# Altium schematic sheet Power.SchDoc
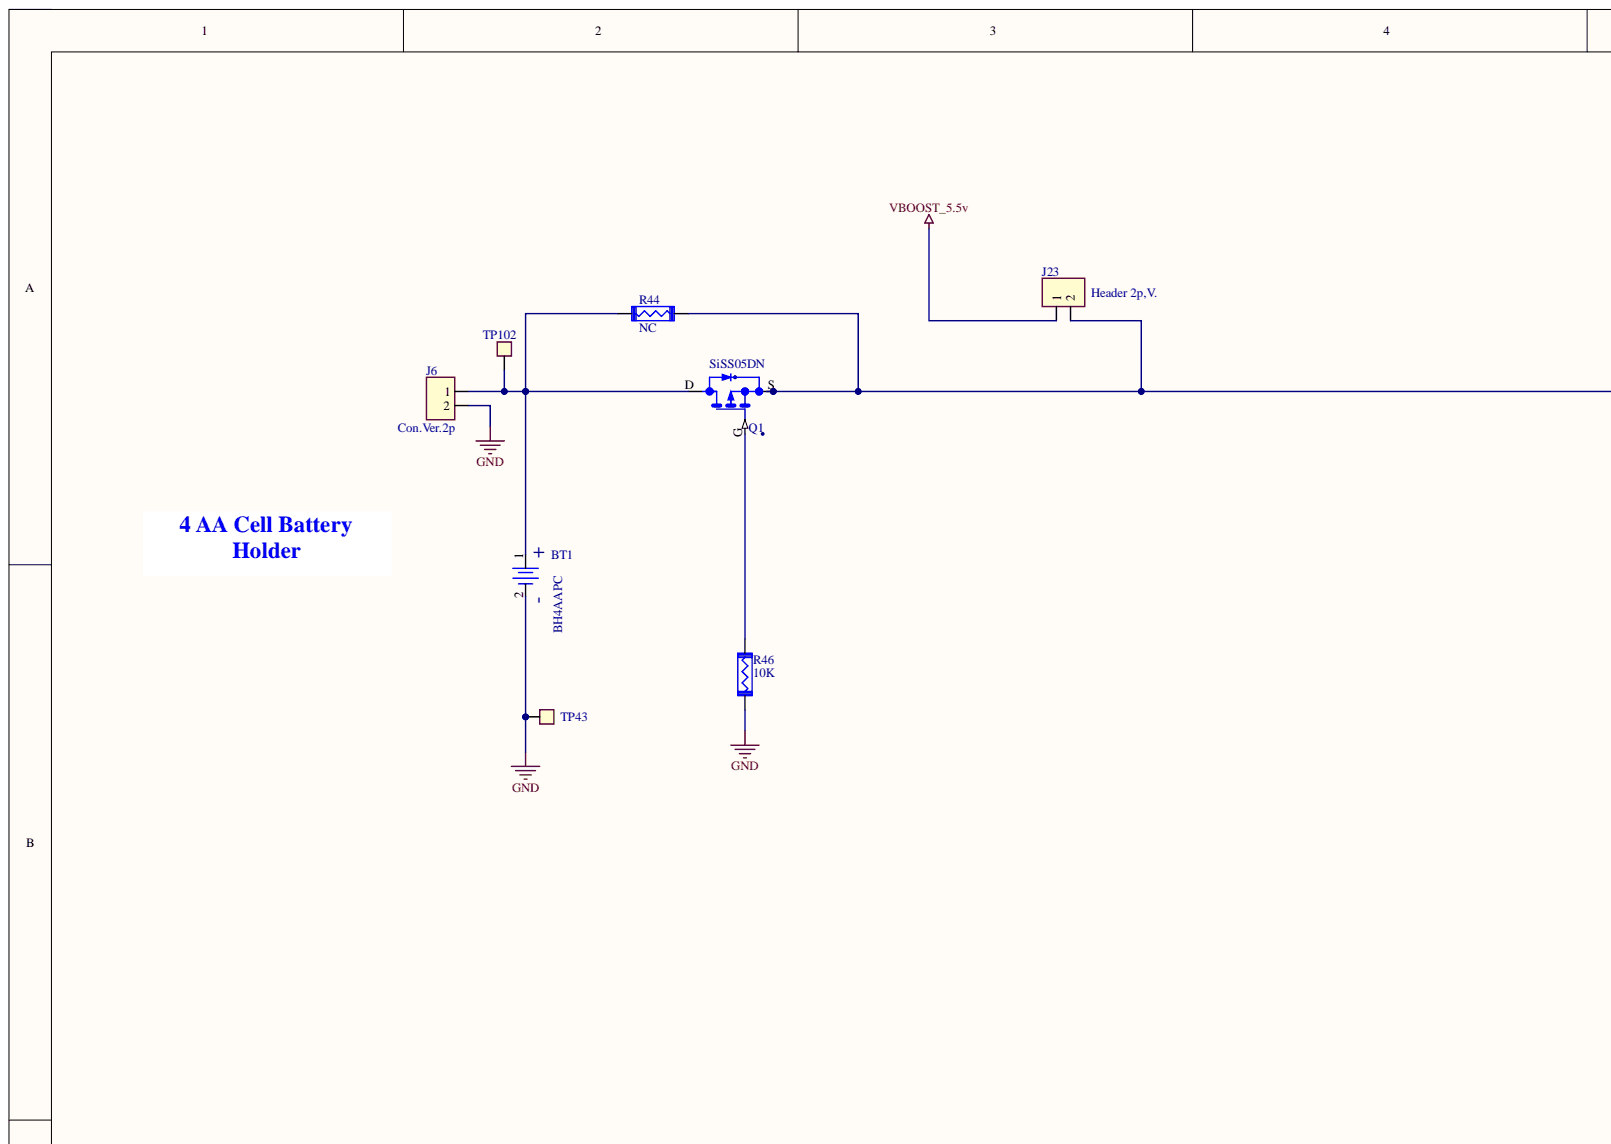
[diagram p0: Power.SchDoc, top left]
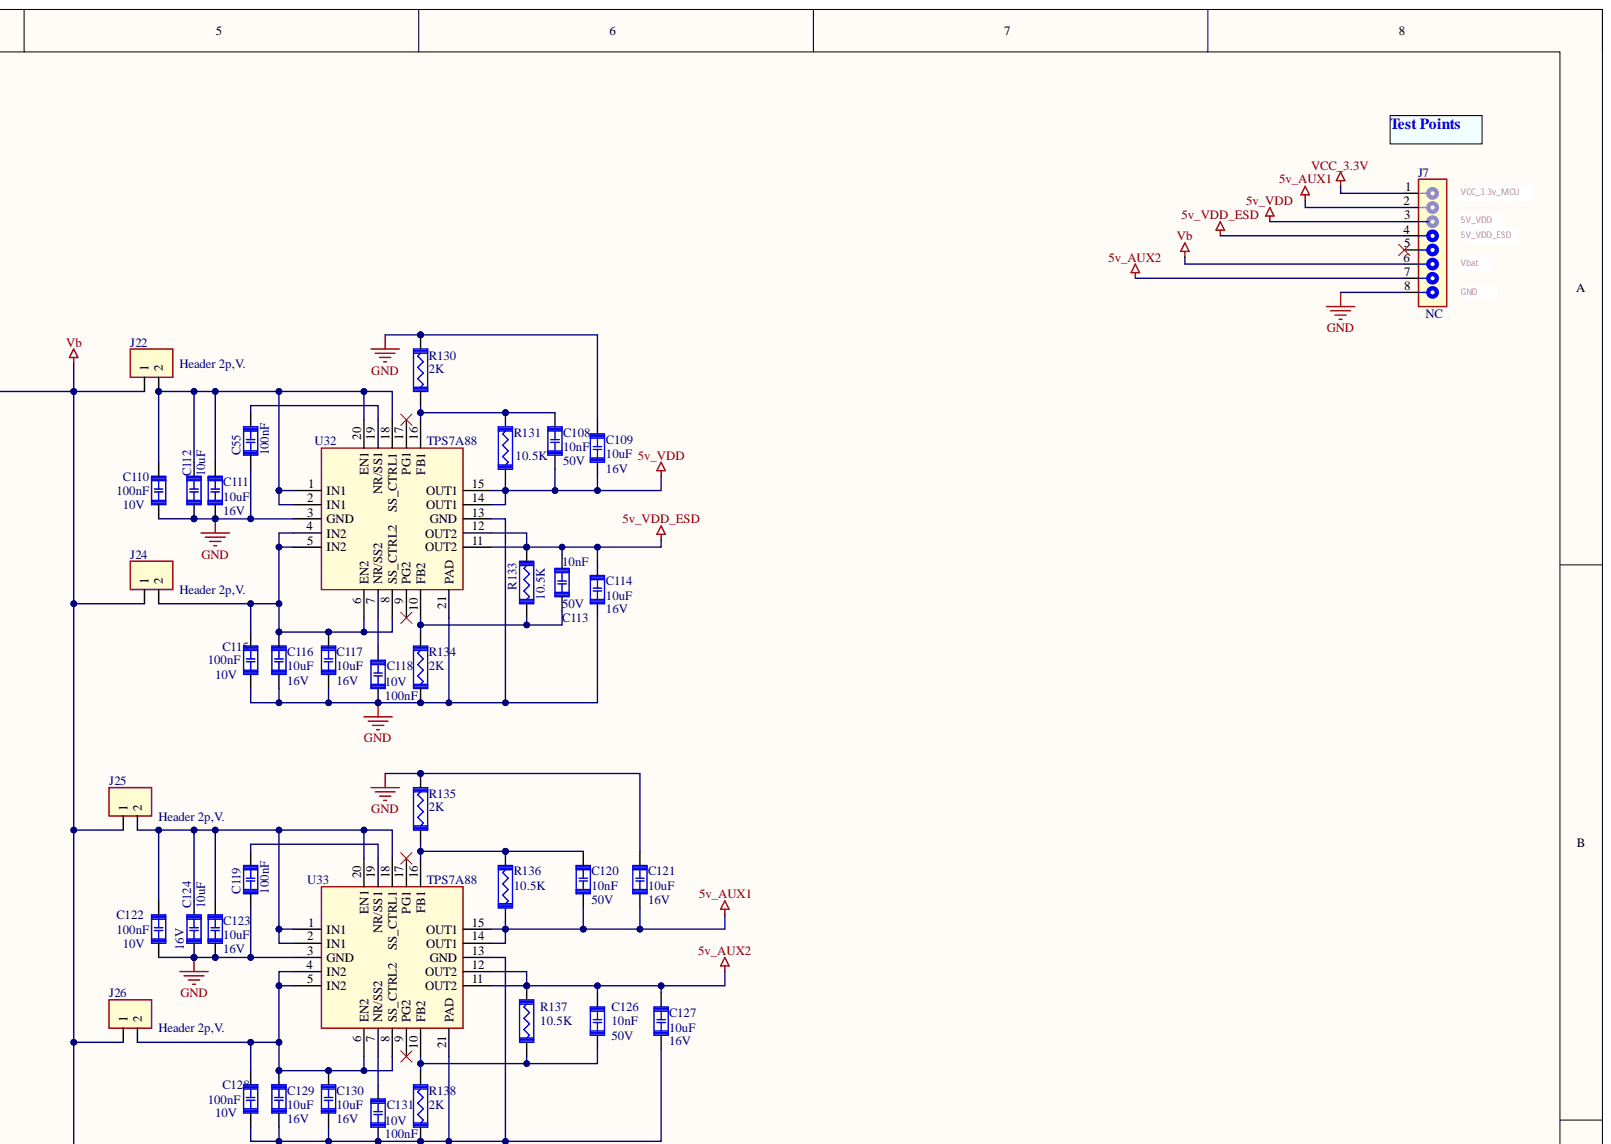
[diagram p1: Power.SchDoc, top right]
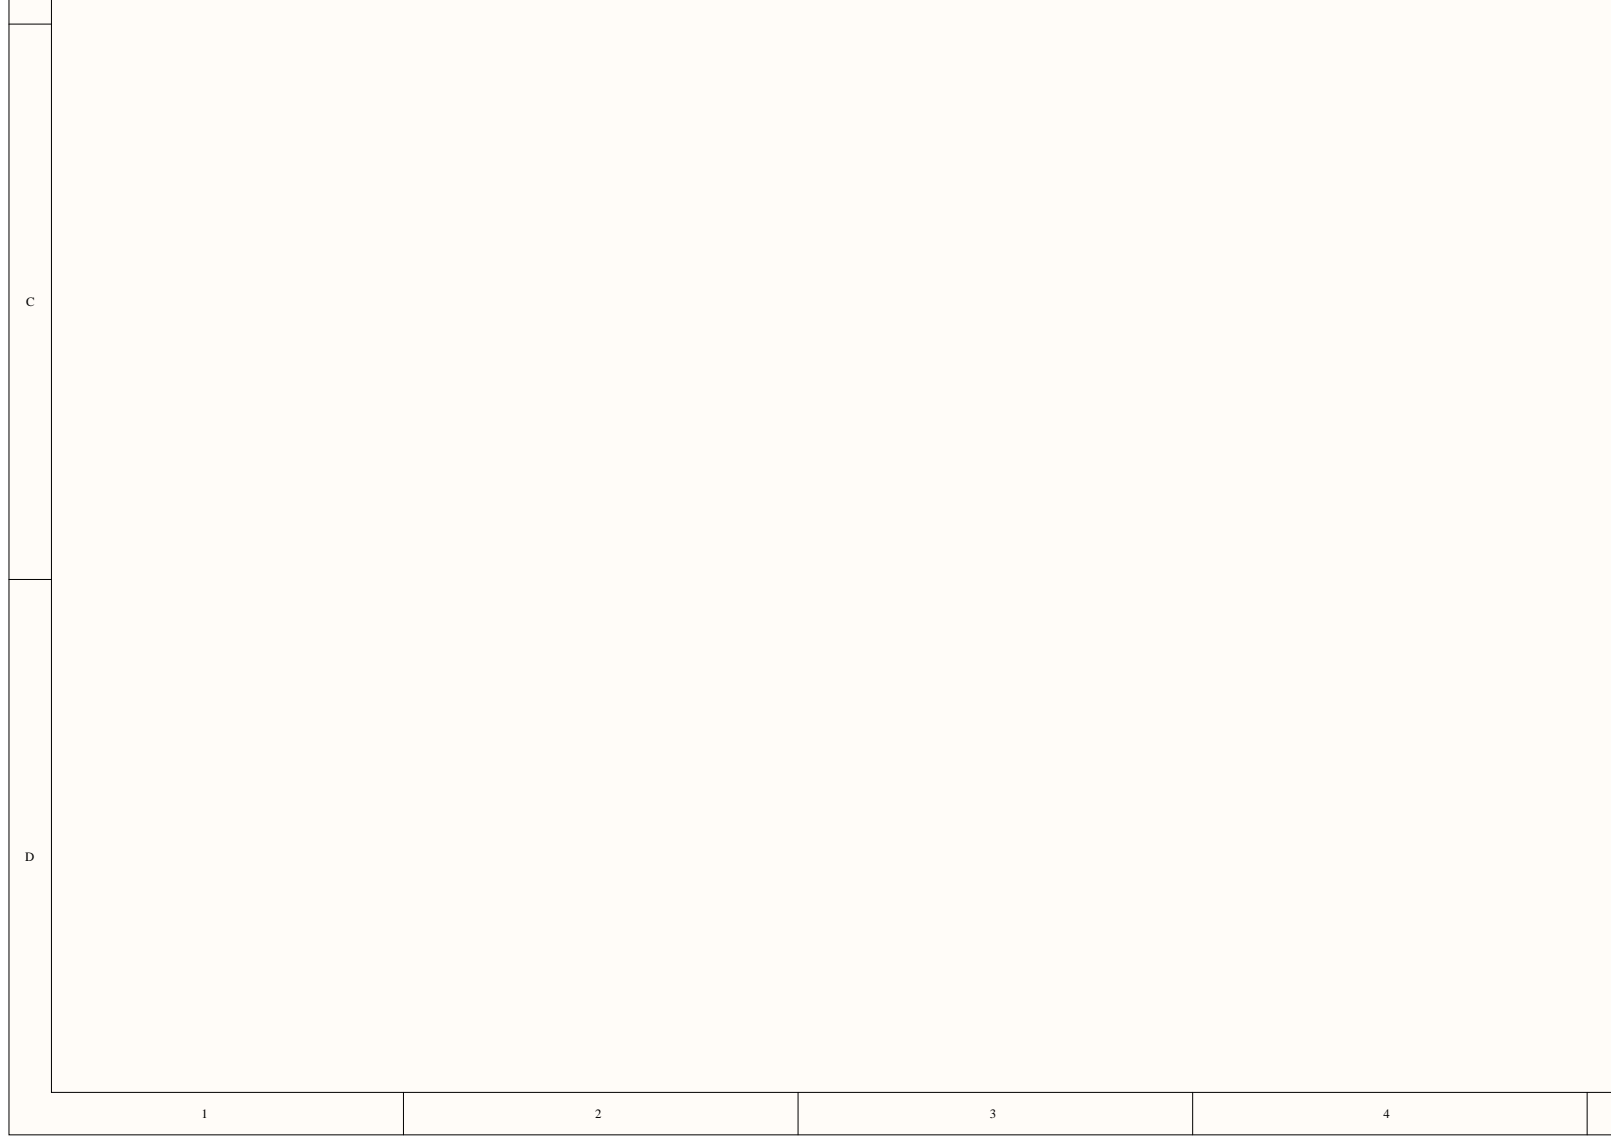
[diagram p2: Power.SchDoc, bottom left]
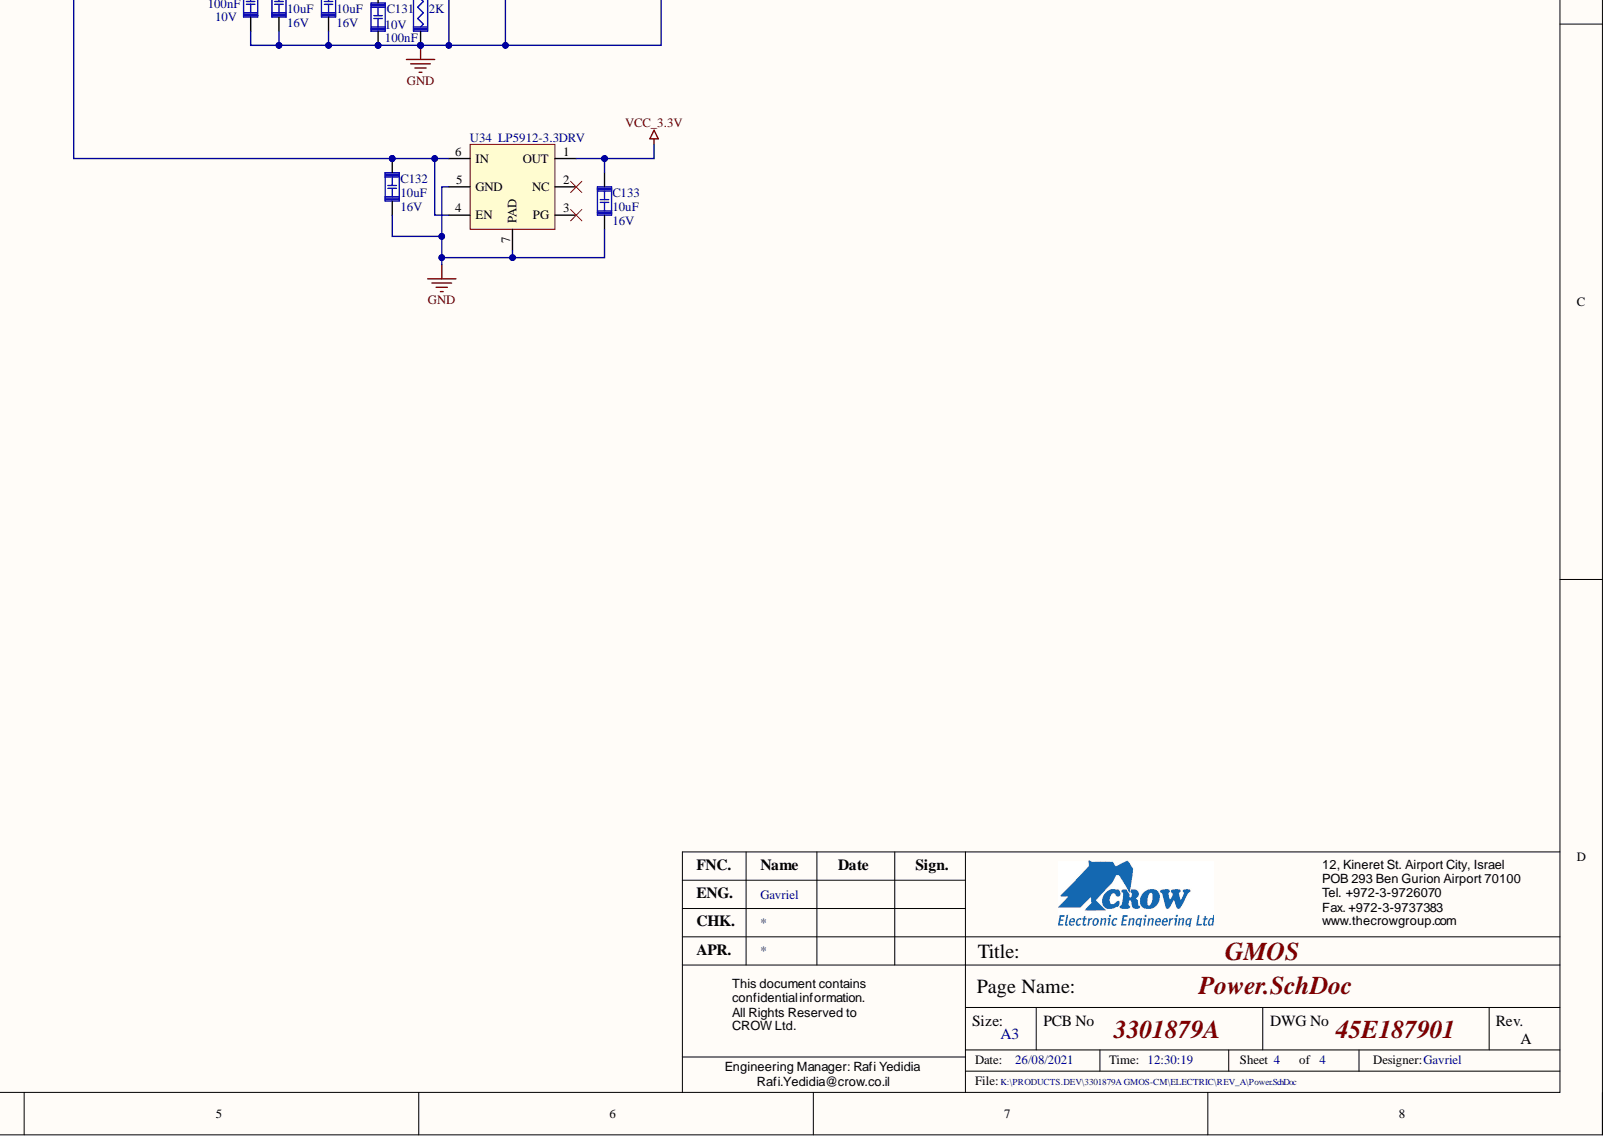
[diagram p3: Power.SchDoc, bottom right]

## Parts
BT1  BH4AAPC  [BAT_BH4AA-PC]
C55  100nF  [C0402/C]
C108  10nF  Voltage: 50V  [C0402/C]
C109  10uF  Voltage: 16V  [C0603/C]
C110  100nF  Voltage: 10V  [C0402/C]
C111  10uF  Voltage: 16V  [C0603/C]
C112  10uF  [C0603/C]
C113  10nF  Voltage: 50V  [C0402/C]
C114  10uF  Voltage: 16V  [C0603/C]
C115  100nF  Voltage: 10V  [C0402/C]
C116  10uF  Voltage: 16V  [C0603/C]
C117  10uF  Voltage: 16V  [C0603/C]
C118  100nF  Voltage: 10V  [C0402/C]
C119  100nF  [C0402/C]
C120  10nF  Voltage: 50V  [C0402/C]
C121  10uF  Voltage: 16V  [C0603/C]
C122  100nF  Voltage: 10V  [C0402/C]
C123  10uF  Voltage: 16V  [C0603/C]
C124  10uF  Voltage: 16V  [C0603/C]
C126  10nF  Voltage: 50V  [C0402/C]
C127  10uF  Voltage: 16V  [C0603/C]
C128  100nF  Voltage: 10V  [C0402/C]
C129  10uF  Voltage: 16V  [C0603/C]
C130  10uF  Voltage: 16V  [C0603/C]
C131  100nF  Voltage: 10V  [C0402/C]
C132  10uF  Voltage: 16V  [C0603/C]
C133  10uF  Voltage: 16V  [C0603/C]
J6  Con.Ver.2p  [JHED2P_2.5MM/C]
J7  NC  [SIP100_8P_3B_H36.5]
J22  Header 2p,V.  [SIP100_2/C]
J23  Header 2p,V.  [SIP100_2/C]
J24  Header 2p,V.  [SIP100_2/C]
J25  Header 2p,V.  [SIP100_2/C]
J26  Header 2p,V.  [SIP100_2/C]
Q1  SiSS05DN  [MOSFET]
R44  NC  [R0402/C]
R46  10K  [R0402/C]
R130  2K  [R0402/C]
R131  10.5K  [R0402/C]
R133  10.5K  [R0402/C]
R134  2K  [R0402/C]
R135  2K  [R0402/C]
R136  10.5K  [R0402/C]
R137  10.5K  [R0402/C]
R138  2K  [R0402/C]
TP43  TP-1.5MM  [TP-1.5mm]  (value not drawn)  (no BOM)
TP102  TP-1.5MM  [TP-1.5mm]  (value not drawn)  (no BOM)
U32  TPS7A88  [QFN20_4x4x1-050]
U33  TPS7A88  [QFN20_4x4x1-050]
U34  LP5912-3.3DRV  [WSON6_2X2_0.65]

## Nets
5v_AUX1: C120.2 C121.2 J7.2 R136.2 U33.14(OUT1) U33.15(OUT1)
5v_AUX2: C126.1 C127.1 J7.7 R137.1 U33.11(OUT2) U33.12(OUT2)
5v_VDD: C108.2 C109.2 J7.3 R131.2 U32.14(OUT1) U32.15(OUT1)
5v_VDD_ESD: C113.1 C114.1 J7.4 R133.1 U32.11(OUT2) U32.12(OUT2)
GND: BT1.2 C55.2 C109.1 C110.2 C111.2 C112.2 C114.2 C115.2 C116.2 C117.2 C118.2 C119.2 C121.1 C122.2 C123.2 C124.2 C127.2 C128.2 C129.2 C130.2 C131.2 C132.2 C133.2 J6.2 J7.8 R46.2 R130.1 R134.2 R135.1 R138.2 TP43.1 U32.3(GND) U32.13(GND) U32.21(PAD) U33.3(GND) U33.13(GND) U33.21(PAD) U34.5(GND) U34.7(PAD)
Vb: C132.1 J7.6 J22.1 J23.2 J24.1 J25.1 J26.1 Q1.S R44.1 U34.4(EN) U34.6(IN)
VBOOST_5.5v: J23.1
VCC_3.3V: C133.1 J7.1 U34.1(OUT)
NetBT1_1: BT1.1 J6.1 Q1.D R44.2 TP102.1
NetC55_1: C55.1 U32.19(NR/SS1)
NetC108_1: C108.1 R130.2 R131.1 U32.16(FB1)
NetC110_1: C110.1 C111.1 C112.1 J22.2 U32.1(IN1) U32.2(IN1) U32.18 U32.20(EN1)
NetC113_2: C113.2 R133.2 R134.1 U32.10(FB2)
NetC115_1: C115.1 C116.1 C117.1 J24.2 U32.4(IN2) U32.5(IN2) U32.6(EN2) U32.8
NetC118_1: C118.1 U32.7(NR/SS2)
NetC119_1: C119.1 U33.19(NR/SS1)
NetC120_1: C120.1 R135.2 R136.1 U33.16(FB1)
NetC122_1: C122.1 C123.1 C124.1 J25.2 U33.1(IN1) U33.2(IN1) U33.18 U33.20(EN1)
NetC126_2: C126.2 R137.2 R138.1 U33.10(FB2)
NetC128_1: C128.1 C129.1 C130.1 J26.2 U33.4(IN2) U33.5(IN2) U33.6(EN2) U33.8
NetC131_1: C131.1 U33.7(NR/SS2)
NetQ1_G: Q1.G R46.1

Not connected: J7 5; U32 9(PG2) 17(PG1); U33 9(PG2) 17(PG1); U34 2(NC) 3(PG)

## Library data of the parts
BT1 = BATTERY: 4 AA cell battery HOLDER; Manufacturer: MPD; Component Kind: Standard; ComponentLink1Description: New link; ComponentLink1URL: https://www.digikey.com/en/products/detail/mpd-memory-protection-devices/BH4AAPC/28932; Value: BH4AAPC; Library Name: Sch_Crow.SchLib
C55 C110 C115 C118 C119 C122 C128 C131 = 1235104: CAP.SMD 100nF 10V X7R 0402 10%; Name Manufacturer#1: EYANG1; Name Manufacturer#2: KEMET; PN Manufacturer#1: 0402X104K100CT; PN Manufacturer#2: C0402C104K8RACT; Database Table Name: ALTM_Capacitors; Component Kind: Standard; ComponentLink1Description: Datasheet; Cost: 0.0005; Crow Part Number: 1235104; Package: SMD; Signal Integrity: Model Name; Simulation: Model Name; Stock: 3379.81; Tolerance: 10%; Value: 100nF; ComponentLink1URL: G:\SPEC\Capacitor\Ceramics\CAP SMD WALSIN.pdf; HelpURL: G:\SPEC\Capacitor\Ceramics\CAP SMD WALSIN.pdf; Library Name: Database_Crow.DbLib
  C55 C119: Voltage: 10V
C108 C113 C120 C126 = 1235103: CAP. SMD 10nF X7R 0402 10%; Name Manufacturer#1: FANGHUA; Name Manufacturer#2: KEMET; PN Manufacturer#1: 0402B103K500NT; PN Manufacturer#2: C0402C103K5RACT; Database Table Name: ALTM_Capacitors; Component Kind: Standard; ComponentLink1Description: Datasheet; Cost: 0.0006; Crow Part Number: 1235103; Package: SMD; Signal Integrity: Model Name; Simulation: Model Name; Stock: 2535.82; Tolerance: 10%; Value: 10nF; ComponentLink1URL: G:\SPEC\Capacitor\Ceramics\CAP SMD WALSIN.pdf; HelpURL: G:\SPEC\Capacitor\Ceramics\CAP SMD WALSIN.pdf; Library Name: Database_Crow.DbLib
C109 C111 C112 C114 C116 C117 C121 C123 C124 C127 C129 C130 C132 C133 = 1236106: CAP. SMD 10uF  0603 16V X5R  20%; Name Manufacturer#1: TDK; PN Manufacturer#1: PC1608X5R1C106M; Database Table Name: ALTM_Capacitors; Component Kind: Standard; ComponentLink1Description: Datasheet; Cost: 0.0306; Crow Part Number: 1236106; Package: SMD; Signal Integrity: Model Name; Simulation: Model Name; Stock: 33.18; Tolerance: 20%; Value: 10uF; Library Name: Database_Crow.DbLib
  C112: Voltage: 16V
J6 = 1612174: CONN  MALE 2 PIN+POL; Name Manufacturer#1: AMTEK; Name Manufacturer#2: CVILUX; PN Manufacturer#1: 2506S-02TW; PN Manufacturer#2: CI25-02P1V00; Database Table Name: ALTM_Connectors; Component Kind: Standard; ComponentLink1Description: Datasheet; Cost: 0.0173; Crow Part Number: 1612174; Package: TH; Stock: 4640; Value: Con.Ver.2p; ComponentLink1URL: G:\SPEC\Connectors\2506x_amtek.pdf; HelpURL: G:\SPEC\Connectors\2506x_amtek.pdf; Library Name: Database_Crow.DbLib
J7 = CON HEAD 8P: Component Kind: Standard; Library Name: Sch_Crow.SchLib
J22-J26 = 1612002: HEADER 2 PIN FOR PCB GOLD 2.54mm; Name Manufacturer#1: CIXI XINYA; Name Manufacturer#2: SYUIN; PN Manufacturer#1: 112-SS02G 2.54m; PN Manufacturer#2: 1701S-116-02G2; Database Table Name: ALTM_Connectors; Component Kind: Standard; ComponentLink1Description: Datasheet; Cost: 0.0029; Crow Part Number: 1612002; Package: TH; Stock: 33.29; Value: Header 2p,V.; ComponentLink1URL: G:\SPEC\Connectors\headers\1701S-118-XXG2(A)-suying.pdf; HelpURL: G:\SPEC\Connectors\headers\1701S-118-XXG2(A)-suying.pdf; Library Name: Database_Crow.DbLib
Q1 = MOSFET_SiSS05DN: Component Kind: Standard; Value: SiSS05DN; Library Name: Sch_Crow.SchLib
R44 = 1120001: SMD RESISTOR 0 ohm 0402 5%; Name Manufacturer#1: VIKING; Name Manufacturer#2: PDC; PN Manufacturer#1: CR-02JL7----0R; PN Manufacturer#2: FCF02JV000; Database Table Name: ALTM_Resistors and Potentiometers; Power: 63mW; Value: 0R; Component Kind: Standard; ComponentLink1Description: Datasheet; Cost: 0.0005; Crow Part Number: 1120001; Package: SMD; Signal Integrity: Model Name; Simulation: Model Name; Stock: 1404.73; Tolerance: 5%; Library Name: Database_Crow.DbLib
R46 = 1134103: SMD RESISTOR 10Kohm   0402 1%; Name Manufacturer#1: ROYAL OHM; Name Manufacturer#2: VIKING; PN Manufacturer#1: 0402WGF1002TCE; PN Manufacturer#2: CR-02FL6---10K; Database Table Name: ALTM_Resistors and Potentiometers; Power: 63mW; Component Kind: Standard; ComponentLink1Description: Datasheet; Cost: 0.0012; Crow Part Number: 1134103; Package: SMD; Signal Integrity: Model Name; Simulation: Model Name; Stock: 845.29; Tolerance: 1%; Value: 10K; Library Name: Database_Crow.DbLib
R130 R134 R135 R138 = 1120202: SMD RESISTOR 2 Kohm 0402 5%; Name Manufacturer#1: VIKING; Name Manufacturer#2: YAGEO; PN Manufacturer#1: CR-02JL7---2K0; PN Manufacturer#2: RC0402JR-072KL; Database Table Name: ALTM_Resistors and Potentiometers; Power: 63mW; Component Kind: Standard; ComponentLink1Description: Datasheet; Cost: 0.0006; Crow Part Number: 1120202; Package: SMD; Signal Integrity: Model Name; Simulation: Model Name; Stock: 25.91; Tolerance: 5%; Value: 2K; Library Name: Database_Crow.DbLib
R131 R133 R136 R137 = R: 10.5K 0402 E96 1%; Component Kind: Standard; Signal Integrity: Model Name; Simulation: Model Name; Value: 10.5K; Library Name: Sch_Crow.SchLib
U32 U33 = TPS7A88_QFN20: Component Kind: Standard; Value: TPS7A88; Library Name: Sch_Crow.SchLib
U34 = LP5912_WSON6: Component Kind: Standard; Description: LP5912-3.3DRV; Value: LP5912-3.3DRV; Library Name: Sch_Crow.SchLib
TP43 TP102 = TP-1.5MM: Library Name: Sch_Crow.SchLib
